# Altium schematic sheet main.SchDoc(main)
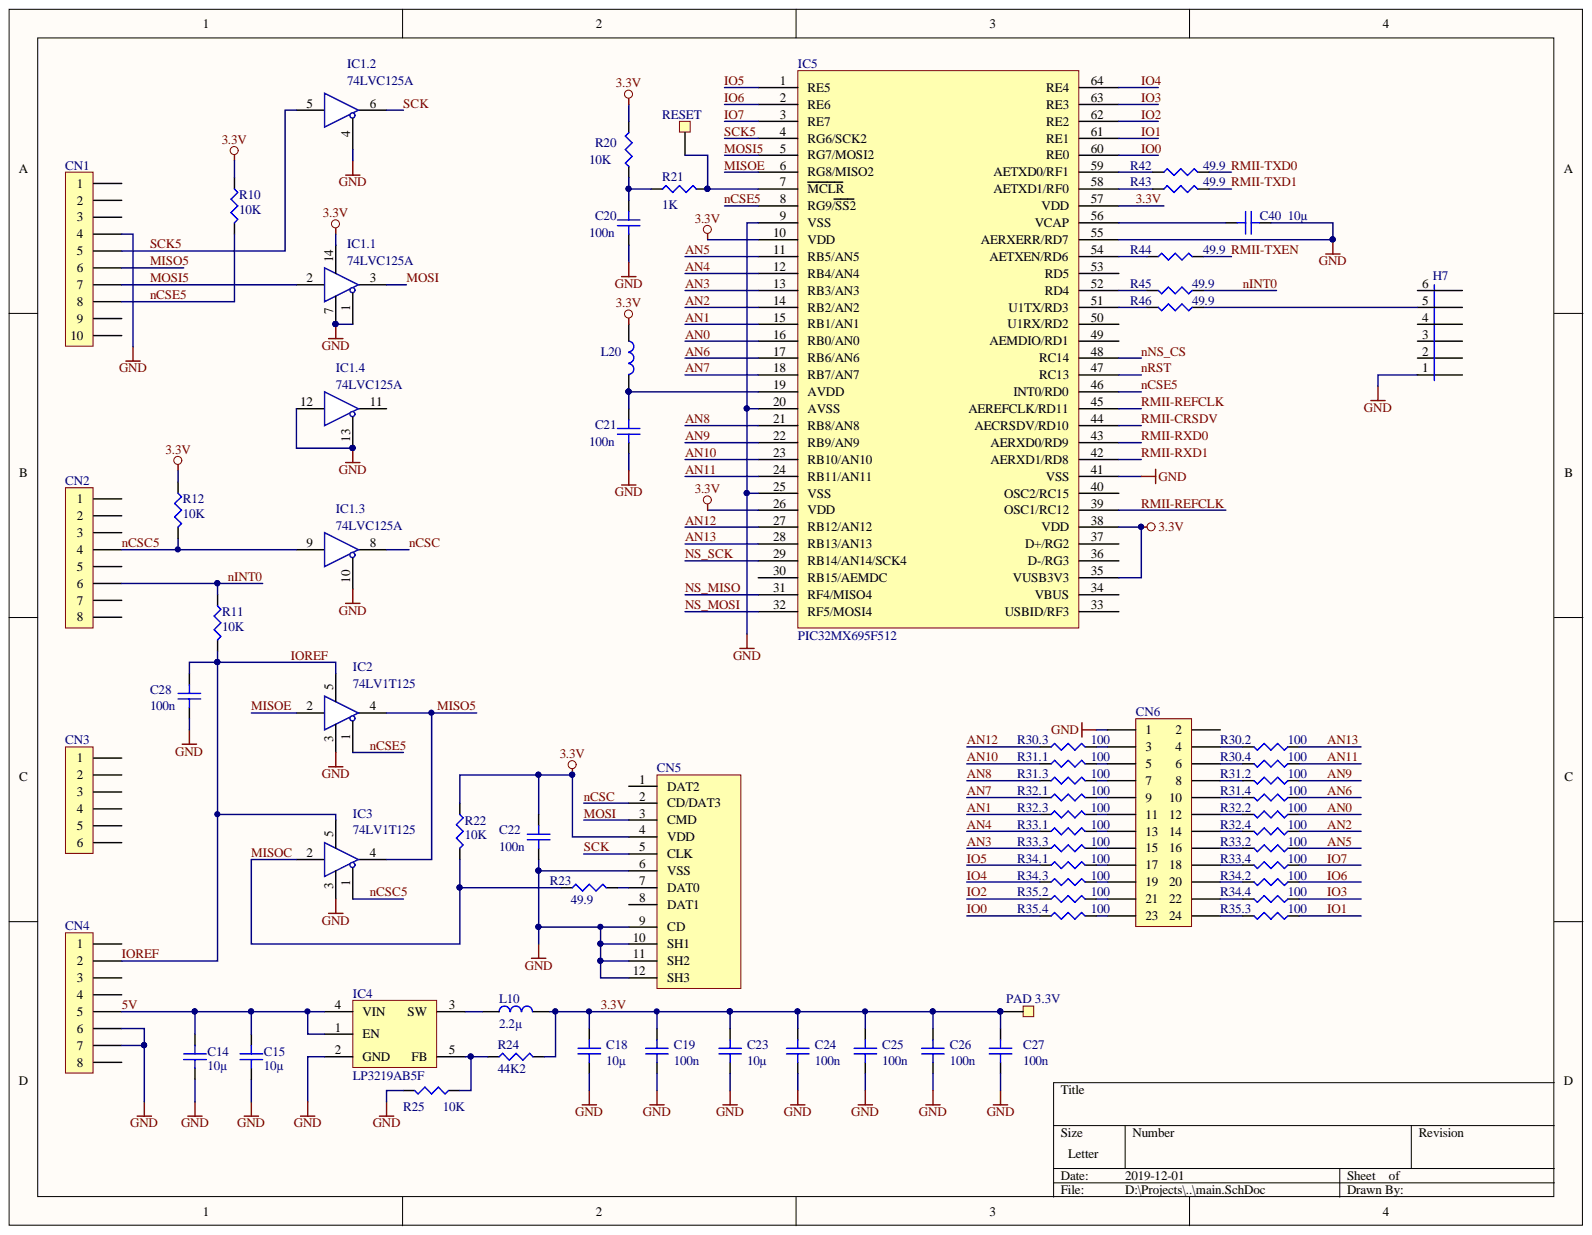
[diagram p0: main.SchDoc]

## Parts
C14  10µ  [0603_C]
C15  10µ  [0603_C]
C18  10µ  [0603_C]
C19  100n  [0402_C]
C20  100n  [0402_C]
C21  100n  [0402_C]
C22  100n  [0402_C]
C23  10µ  [0603_C]
C24  100n  [0402_C]
C25  100n  [0402_C]
C26  100n  [0402_C]
C27  100n  [0402_C]
C28  100n  [0402_C]
C40  10µ  [0603_C]
CN1  (no value)  [PIN SOCKET 1x10 P2.54]
CN2  (no value)  [PIN SOCKET 1x8 P2.54]
CN3  (no value)  [PIN SOCKET 1x6 P2.54]
CN4  (no value)  [PIN SOCKET 1x8 P2.54]
CN5  (no value)  [MicroSD-40KDH]
CN6  (no value)  [PIN SOCKET 2x12 P2.54]
H7  (no value)  [PIN HEADER 1x6 P2.54]
IC1  74LVC125A  [TSSOP14]  (parts .1,.2,.3,.4)
IC2  74LV1T125  [SC70-5]
IC3  74LV1T125  [SC70-5]
IC4  LP3219AB5F  [SOT23-5]
IC5  PIC32MX695F512  [TQFP64 10x10 P0.5]
L10  2.2µ  [1008]
L20  (no value)  [0402_R]
PAD_3.3V  PAD SMD  [PAD SMD OD2]  (value not drawn)  (no BOM)
R10  10K  [0402_R]
R11  10K  [0402_R]
R12  10K  [0402_R]
R20  10K  [0402_R]
R21  1K  [0402_R]
R22  10K  [0402_R]
R23  49.9  [0402_R]
R24  44K2  [0402_R]
R25  10K  [0402_R]
R30  100  [1206_4R]  (parts .2,.3,.4)
R31  100  [1206_4R]  (parts .1,.2,.3,.4)
R32  100  [1206_4R]  (parts .1,.2,.3,.4)
R33  100  [1206_4R]  (parts .1,.2,.3,.4)
R34  100  [1206_4R]  (parts .1,.2,.3,.4)
R35  100  [1206_4R]  (parts .2,.3,.4)
R42  49.9  [0402_R]
R43  49.9  [0402_R]
R44  49.9  [0402_R]
R45  49.9  [0402_R]
R46  49.9  [0402_R]
RESET  PAD TH  [PAD SMD OD2]  (value not drawn)  (no BOM)

## Nets
GND: C14.1 C15.1 C18.1 C19.2 C20.1 C21.1 C22.1 C23.1 C24.1 C25.1 C26.1 C27.1 C28.1 C40.1 CN1.4 CN4.6 CN4.7 CN5.6(VSS) CN5.9(CD) CN5.10(SH1) CN5.11(SH2) CN5.12(SH3) CN6.1 H7.1 IC1.1 IC1.4 IC1.7 IC1.10 IC1.12 IC1.13 IC2.3 IC3.3 IC4.2(GND) IC5.9(VSS) IC5.20(AVSS) IC5.25(VSS) IC5.41(VSS) IC5.55(AERXERR/RD7) R25.2
3.3V: C18.2 C19.1 C22.2 C23.2 C24.2 C25.2 C26.2 C27.2 CN5.4(VDD) IC1.14 IC5.10(VDD) IC5.26(VDD) IC5.35(VUSB3V3) IC5.38(VDD) IC5.57(VDD) L10.1 L20.2 PAD_3.3V.1 R10.1 R12.1 R20.2 R22.1 R24.2
5V: C14.2 C15.2 CN4.5 IC4.1(EN) IC4.4(VIN)
AN0: IC5.16(RB0/AN0) R32.3
AN1: IC5.15(RB1/AN1) R32.5
AN2: IC5.14(RB2/AN2) R32.7
AN3: IC5.13(RB3/AN3) R33.5
AN4: IC5.12(RB4/AN4) R33.1
AN5: IC5.11(RB5/AN5) R33.3
AN6: IC5.17(RB6/AN6) R31.7
AN7: IC5.18(RB7/AN7) R32.1
AN8: IC5.21(RB8/AN8) R31.5
AN9: IC5.22(RB9/AN9) R31.3
AN10: IC5.23(RB10/AN10) R31.1
AN11: IC5.24(RB11/AN11) R30.7
AN12: IC5.27(RB12/AN12) R30.5
AN13: IC5.28(RB13/AN13) R30.3
IO0: IC5.60(RE0) R35.7
IO1: IC5.61(RE1) R35.5
IO2: IC5.62(RE2) R35.3
IO3: IC5.63(RE3) R34.7
IO4: IC5.64(RE4) R34.5
IO5: IC5.1(RE5) R34.1
IO6: IC5.2(RE6) R34.3
IO7: IC5.3(RE7) R33.7
IOREF: C28.2 CN4.2 IC2.5 IC3.5 R11.2
MISO5: CN1.6 IC2.4 IC3.4
MISOC: IC3.2 R22.2 R23.1
MISOE: IC2.2 IC5.6(RG8/MISO2)
MOSI: CN5.3(CMD) IC1.3
MOSI5: CN1.7 IC1.2 IC5.5(RG7/MOSI2)
NCSC: CN5.2(CD/DAT3) IC1.8
NCSC5: CN2.4 IC1.9 IC3.1 R12.2
NCSE5: CN1.8 IC2.1 IC5.8(RG9/SS2) IC5.46(INT0/RD0) R10.2
NINT0: CN2.6 R11.1 R45.1
NNS_CS: IC5.48(RC14)
NRST: IC5.47(RC13)
NS_MISO: IC5.31(RF4/MISO4)
NS_MOSI: IC5.32(RF5/MOSI4)
NS_SCK: IC5.29(RB14/AN14/SCK4)
RMII-CRSDV: IC5.44(AECRSDV/RD10)
RMII-REFCLK: IC5.39(OSC1/RC12) IC5.45(AEREFCLK/RD11)
RMII-RXD0: IC5.43(AERXD0/RD9)
RMII-RXD1: IC5.42(AERXD1/RD8)
RMII-TXD0: R42.2
RMII-TXD1: R43.2
RMII-TXEN: R44.2
SCK: CN5.5(CLK) IC1.6
SCK5: CN1.5 IC1.5 IC5.4(RG6/SCK2)
NetC20_2: C20.2 R20.1 R21.1
NetC21_2: C21.2 IC5.19(AVDD) L20.1
NetC40_2: C40.2 IC5.56(VCAP)
NetCN5_7: CN5.7(DAT0) R23.2
NetCN6_3: CN6.3 R30.6
NetCN6_4: CN6.4 R30.4
NetCN6_5: CN6.5 R31.2
NetCN6_6: CN6.6 R30.8
NetCN6_7: CN6.7 R31.6
NetCN6_8: CN6.8 R31.4
NetCN6_9: CN6.9 R32.2
NetCN6_10: CN6.10 R31.8
NetCN6_11: CN6.11 R32.6
NetCN6_12: CN6.12 R32.4
NetCN6_13: CN6.13 R33.2
NetCN6_14: CN6.14 R32.8
NetCN6_15: CN6.15 R33.6
NetCN6_16: CN6.16 R33.4
NetCN6_17: CN6.17 R34.2
NetCN6_18: CN6.18 R33.8
NetCN6_19: CN6.19 R34.6
NetCN6_20: CN6.20 R34.4
NetCN6_21: CN6.21 R35.4
NetCN6_22: CN6.22 R34.8
NetCN6_23: CN6.23 R35.8
NetCN6_24: CN6.24 R35.6
NetH7_5: H7.5 R46.1
NetIC4_3: IC4.3(SW) L10.2
NetIC4_5: IC4.5(FB) R24.1 R25.1
NetIC5_7: IC5.7(MCLR) R21.2 RESET.1
NetIC5_51: IC5.51(U1TX/RD3) R46.2
NetIC5_52: IC5.52(RD4) R45.2
NetIC5_54: IC5.54(AETXEN/RD6) R44.1
NetIC5_58: IC5.58(AETXD1/RF0) R43.1
NetIC5_59: IC5.59(AETXD0/RF1) R42.1

Not connected: CN1 1 2 3 9 10; CN2 1 2 3 5 7 8; CN3 1 2 3 4 5 6; CN4 1 3 4 8; CN5 1(DAT2) 8(DAT1); CN6 2; H7 2 3 4 6; IC1 11; IC5 30(RB15/AEMDC) 33(USBID/RF3) 34(VBUS) 36(D-/RG3) 37(D+/RG2) 40(OSC2/RC15) 49(AEMDIO/RD1) 50(U1RX/RD2) 53(RD5)

## Library data of the parts
C14 C15 = Cap: CAP CER 10UF 10V X5R 0603; Manufacturer: SAMSUNG E-M; Part Number: CL10A106MP8NNNC; Component Kind: Standard; Library Name: SchLib.SchLib
C18 C23 C40 = Cap: CAP CER 10UF 6.3V X5R 0603; Manufacturer: SAMSUNG E-M; Part Number: CL10A106MQ8NNNC; Component Kind: Standard; Library Name: SchLib.SchLib
C19-C22 C24-C28 = Cap: CAP CER 0.1UF 16V X7R 0402; Manufacturer: YAGEO; Part Number: CC0402KRX7R7BB104; Component Kind: Standard; Library Name: SchLib.SchLib
CN1 = Connector 1x10: PIN SOCKET 10POS 2.54MM; Manufacturer: LIJING ELECTRONIC; Part Number: LJFH2.54X8.5S-1010P; Component Kind: Standard; Library Name: SchLib.SchLib
CN2 CN4 = Connector 1x8: PIN SOCKET 8POS 2.54MM; Manufacturer: LIJING ELECTRONIC; Part Number: LJFH2.54X8.5S-1008P; Component Kind: Standard; Library Name: SchLib.SchLib
CN3 = Connector 1x6: PIN SOCKET 6POS 2.54MM; Manufacturer: LIJING ELECTRONIC; Part Number: LJFH2.54X8.5S-1006P; Component Kind: Standard; Library Name: SchLib.SchLib
CN5 = microSD1: microSD SOCKET; Manufacturer: SOFNG; Part Number: TF-001B; Component Kind: Standard; Library Name: SchLib.SchLib
CN6 = Connector 2x12: PIN SOCKET 2X12POS 2.54MM; Manufacturer: CONNFLYELEC; Part Number: DS1023-2*12SF11; Component Kind: Standard; Library Name: SchLib.SchLib
H7 = Header 1x6: PIN HEADER 1X6POS 2.54MM; Manufacturer: BOOM PRECISION ELEC; Part Number: LCSC# C37208; Component Kind: Standard; Library Name: SchLib.SchLib
IC1 = 74LVC125A: IC BUF NON-INVERT 3.6V 14TSSOP; Manufacturer: NEXPERIA; Part Number: 74LVC125APW,118; Component Kind: Standard; Library Name: SchLib.SchLib
IC2 IC3 = 74LV1T125: IC BUF NON-INVERT 5V SC70-5; Manufacturer: TI; Part Number: SN74LV1T125DCKR; Component Kind: Standard; Library Name: SchLib.SchLib
IC4 = AP3410: IC REG BUCK ADJ 2A SYNC SOT23-5; Manufacturer: LOW POWER; Component Kind: Standard; Library Name: SchLib.SchLib; Part Number: LP3219AB5F
IC5 = PIC32MX6: IC MCU 32BIT 512KB FLASH 64TQFP; Manufacturer: MICROCHIP; Part Number: PIC32MX695F512H-80I/PT; Component Kind: Standard; Library Name: SchLib.SchLib
L10 = Inductor: FIXED IND 2.2UH 1.9A 0.1OHM 1008; Manufacturer: TAIYO YUDEN; Part Number: MAMK2520T2R2M; Component Kind: Standard; Library Name: SchLib.SchLib
L20 = Inductor: FERRITE BEAD 120/55 OHM 1.1A 0402; Manufacturer: Murata; Part Number: BLM15PX121SN1D; Component Kind: Standard; Library Name: SchLib.SchLib
PAD_3.3V RESET = PAD: PAD SMD OD2; Library Name: SchLib.SchLib
R10-R12 R20 R22 R25 = Res: RES 10K OHM 1% 0.063W 0402; Manufacturer: YAGEO; Part Number: RC0402FR-0710KL; Component Kind: Standard; Library Name: SchLib.SchLib
R21 = Res: RES 1K OHM 1% 0.063W 0402; Manufacturer: YAGEO; Part Number: RC0402FR-071KL; Component Kind: Standard; Library Name: SchLib.SchLib
R23 R42-R46 = Res: RES 49.9 OHM 1% 0.063W 0402; Manufacturer: YAGEO; Part Number: RC0402FR-0749R9L; Component Kind: Standard; Library Name: SchLib.SchLib
R24 = Res: RES 44.2K OHM 1% 0.063W 0402; Manufacturer: YAGEO; Part Number: RC0402FR-0744K2L; Component Kind: Standard; Library Name: SchLib.SchLib
R30-R35 = Res_quad: RES ARRAY 4x100 OHM 5% 1206; Manufacturer: BOURNS; Part Number: CAY16-101J4LF; Component Kind: Standard; Library Name: SchLib.SchLib
